# Altium schematic sheet Topology1.SchDoc
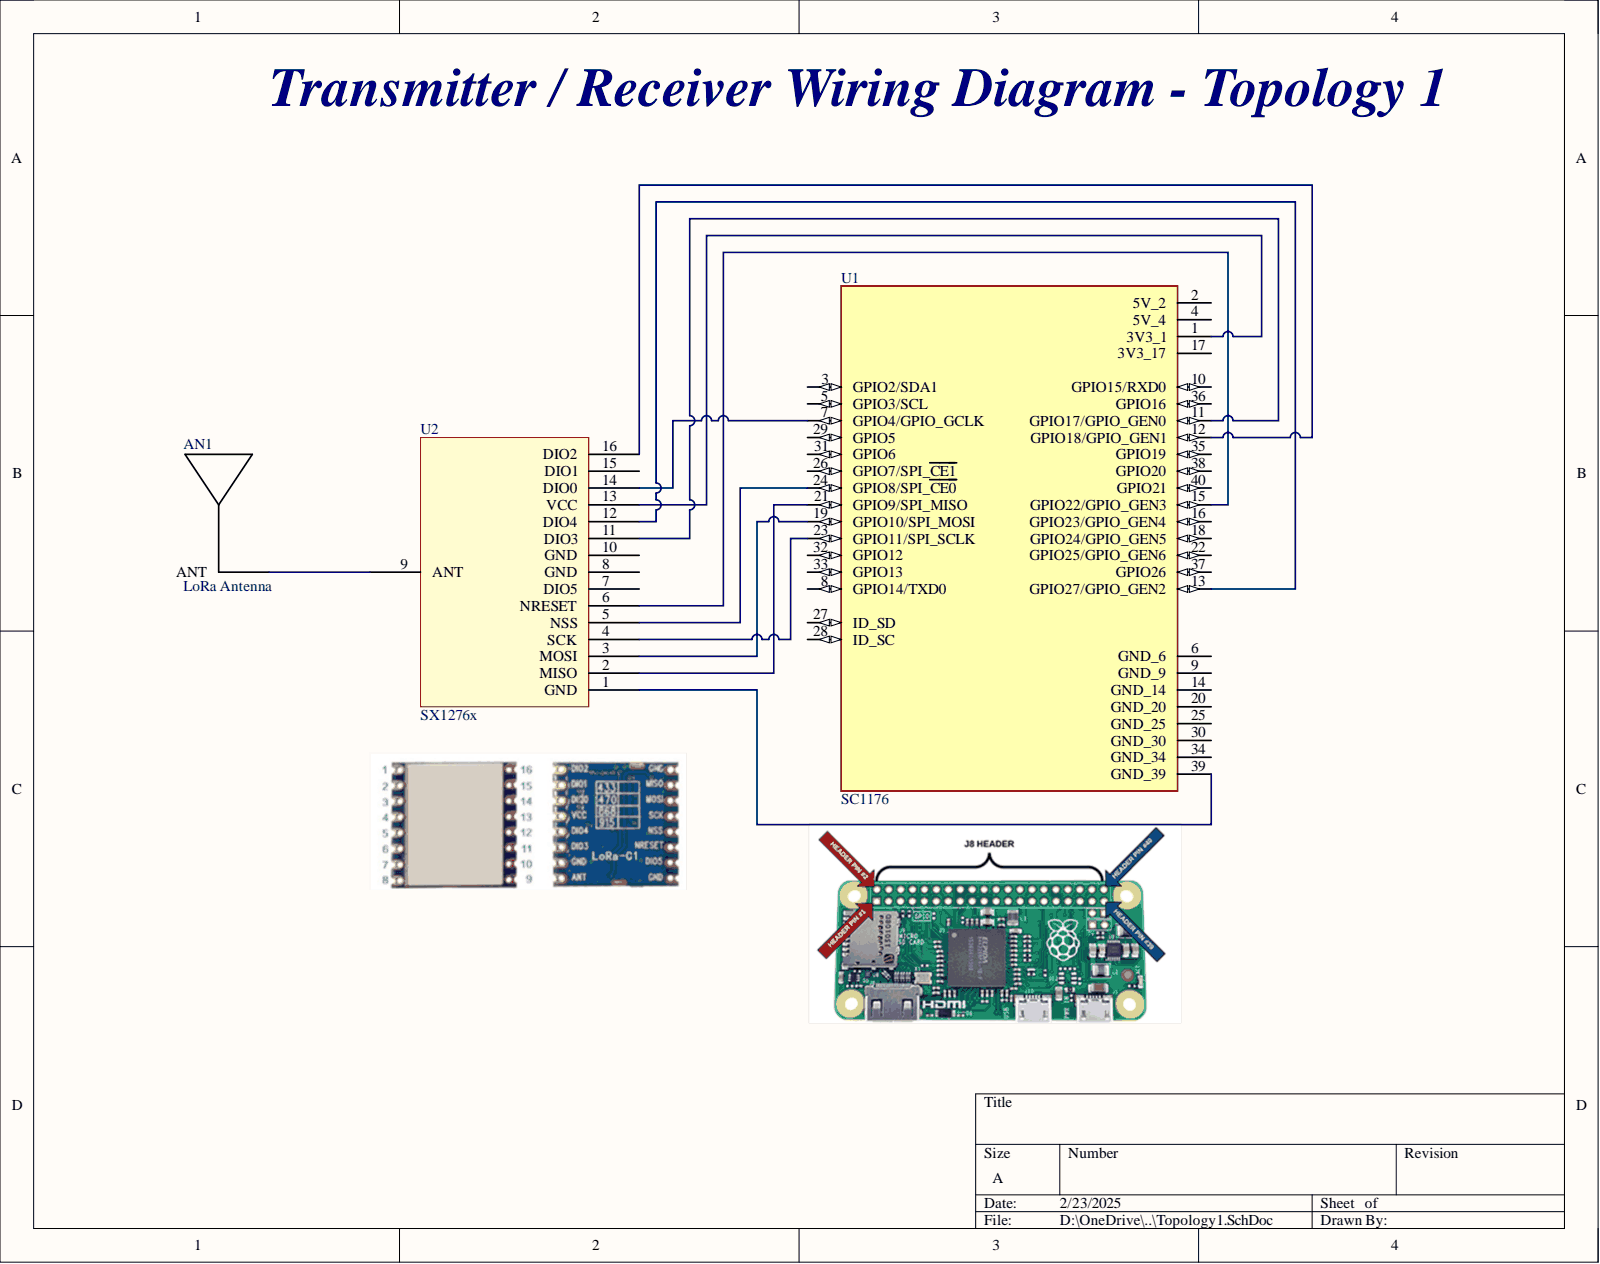
[diagram p0: Topology1.SchDoc]

## Parts
AN1  LoRa Antenna
U1  SC1176  [MODULE_SC1176]
U2  SX1276x

## Nets
NetAN1: AN1. U2.9(ANT)
NetU1_1: U1.1(3V3_1) U2.13(VCC)
NetU1_2: U1.2(5V_2)
NetU1_3: U1.3(GPIO2/SDA1)
NetU1_4: U1.4(5V_4)
NetU1_5: U1.5(GPIO3/SCL)
NetU1_6: U1.6(GND_6)
NetU1_7: U1.7(GPIO4/GPIO_GCLK) U2.14(DIO0)
NetU1_8: U1.8(GPIO14/TXD0)
NetU1_9: U1.9(GND_9)
NetU1_10: U1.10(GPIO15/RXD0)
NetU1_11: U1.11(GPIO17/GPIO_GEN0) U2.11(DIO3)
NetU1_12: U1.12(GPIO18/GPIO_GEN1) U2.16(DIO2)
NetU1_13: U1.13(GPIO27/GPIO_GEN2) U2.12(DIO4)
NetU1_14: U1.14(GND_14)
NetU1_15: U1.15(GPIO22/GPIO_GEN3) U2.6(NRESET)
NetU1_16: U1.16(GPIO23/GPIO_GEN4)
NetU1_17: U1.17(3V3_17)
NetU1_18: U1.18(GPIO24/GPIO_GEN5)
NetU1_19: U1.19(GPIO10/SPI_MOSI) U2.3(MOSI)
NetU1_20: U1.20(GND_20)
NetU1_21: U1.21(GPIO9/SPI_MISO) U2.2(MISO)
NetU1_22: U1.22(GPIO25/GPIO_GEN6)
NetU1_23: U1.23(GPIO11/SPI_SCLK) U2.4(SCK)
NetU1_24: U1.24(GPIO8/SPI_CE0) U2.5(NSS)
NetU1_25: U1.25(GND_25)
NetU1_26: U1.26(GPIO7/SPI_CE1)
NetU1_27: U1.27(ID_SD)
NetU1_28: U1.28(ID_SC)
NetU1_29: U1.29(GPIO5)
NetU1_30: U1.30(GND_30)
NetU1_31: U1.31(GPIO6)
NetU1_32: U1.32(GPIO12)
NetU1_33: U1.33(GPIO13)
NetU1_34: U1.34(GND_34)
NetU1_35: U1.35(GPIO19)
NetU1_36: U1.36(GPIO16)
NetU1_37: U1.37(GPIO26)
NetU1_38: U1.38(GPIO20)
NetU1_39: U1.39(GND_39) U2.1(GND)
NetU1_40: U1.40(GPIO21)
NetU2_7: U2.7(DIO5)
NetU2_8: U2.8(GND)
NetU2_10: U2.10(GND)
NetU2_15: U2.15(DIO1)

## Library data of the parts
U1 = SC1176: The Raspberry Pi Zero 2 W SC1176 single board computer features a 1GHz quad-core Broadcom BCM2710A1 Arm Cortex-A53 processor and 512MB of RAM, making it a powerful solution for embedded computing applications. With 40 digital I/O lines and multiple expansion options including Bluetooth 4.2, BLE, HAT GPIO, and LAN, this SBC offers extensive connectivity and flexibility. Its compact size of 2.600 x 1.180 (66.04mm x 29.97mm) makes it ideal for space-constrained projects. Video outputs include Composite and HDMI, and it features a microSD storage interface and a USB 2.0 OTG port.; Check_prices: https://www.snapeda.com/parts/SC1176/Raspberry+Pi/view-part/?ref=eda; Component Kind: Standard; Library Name: Schlib1.SchLib; MF: Raspberry Pi; MP: SC1176; Package: None; SnapEDA_Link: https://www.snapeda.com/parts/SC1176/Raspberry+Pi/view-part/?ref=snap
AN1 = LoRa Antenna: Component Kind: Standard; Library Name: Schlib1.SchLib
U2 = SX1276x: Component Kind: Standard; Library Name: Schlib1.SchLib
